# Altium schematic sheet MainSheet.SchDoc(MainSheet)
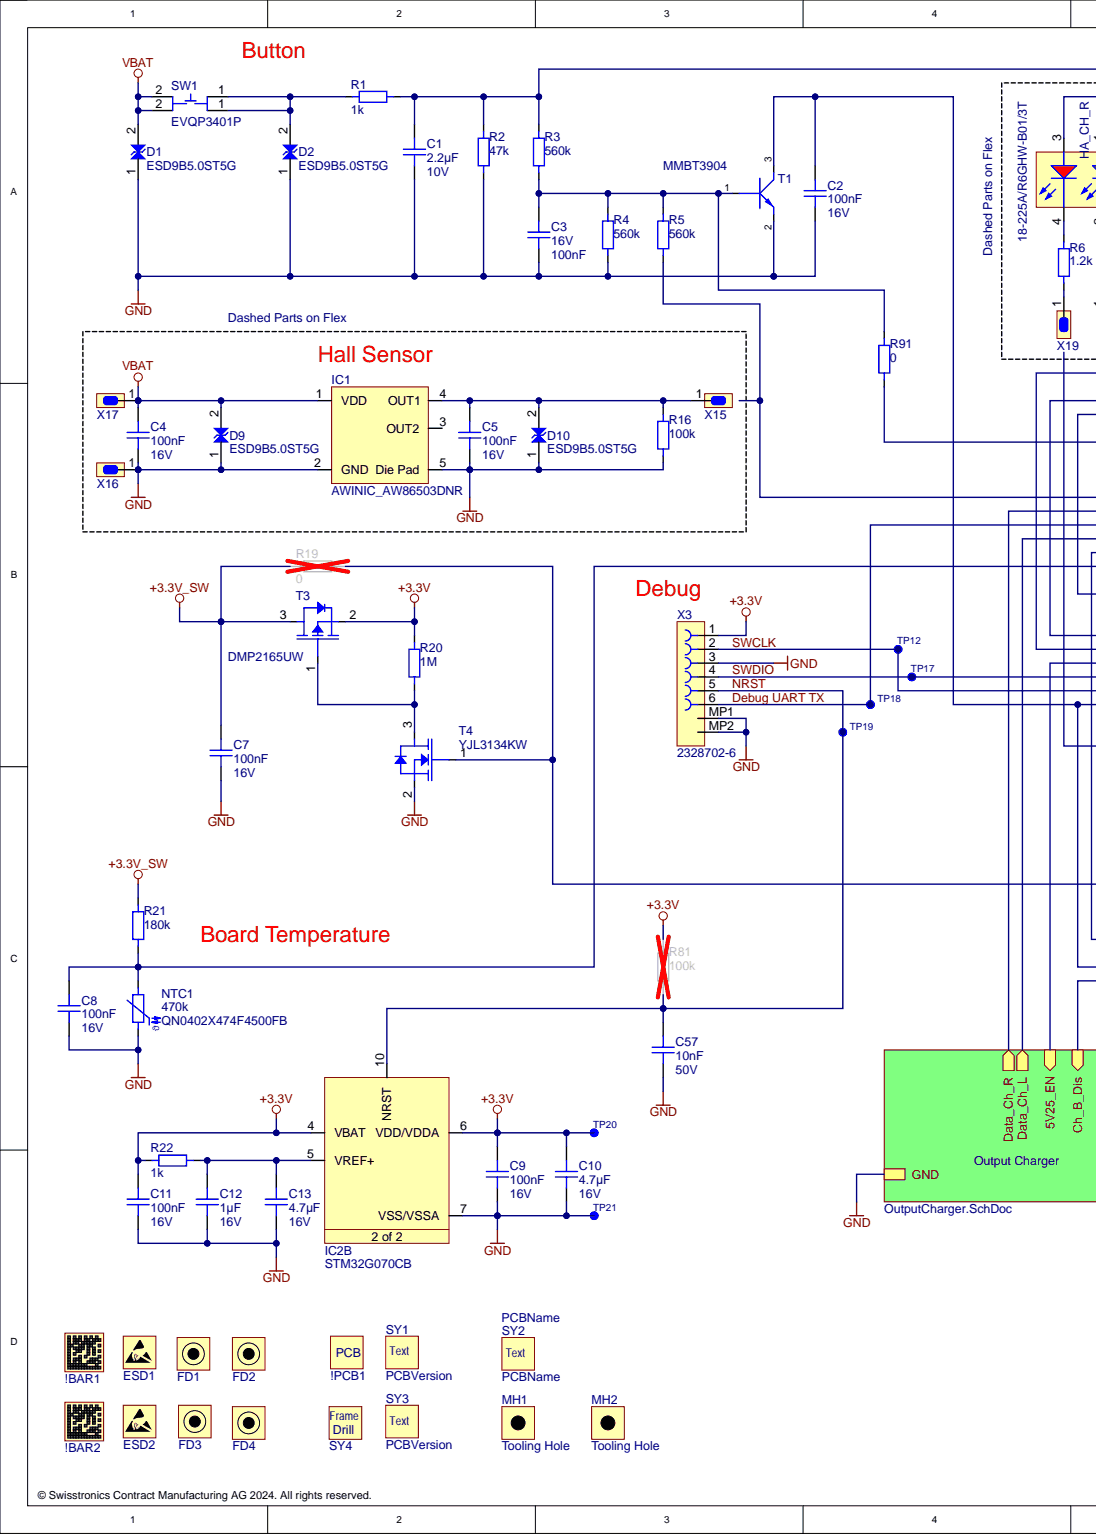
[diagram p0: MainSheet.SchDoc, left]
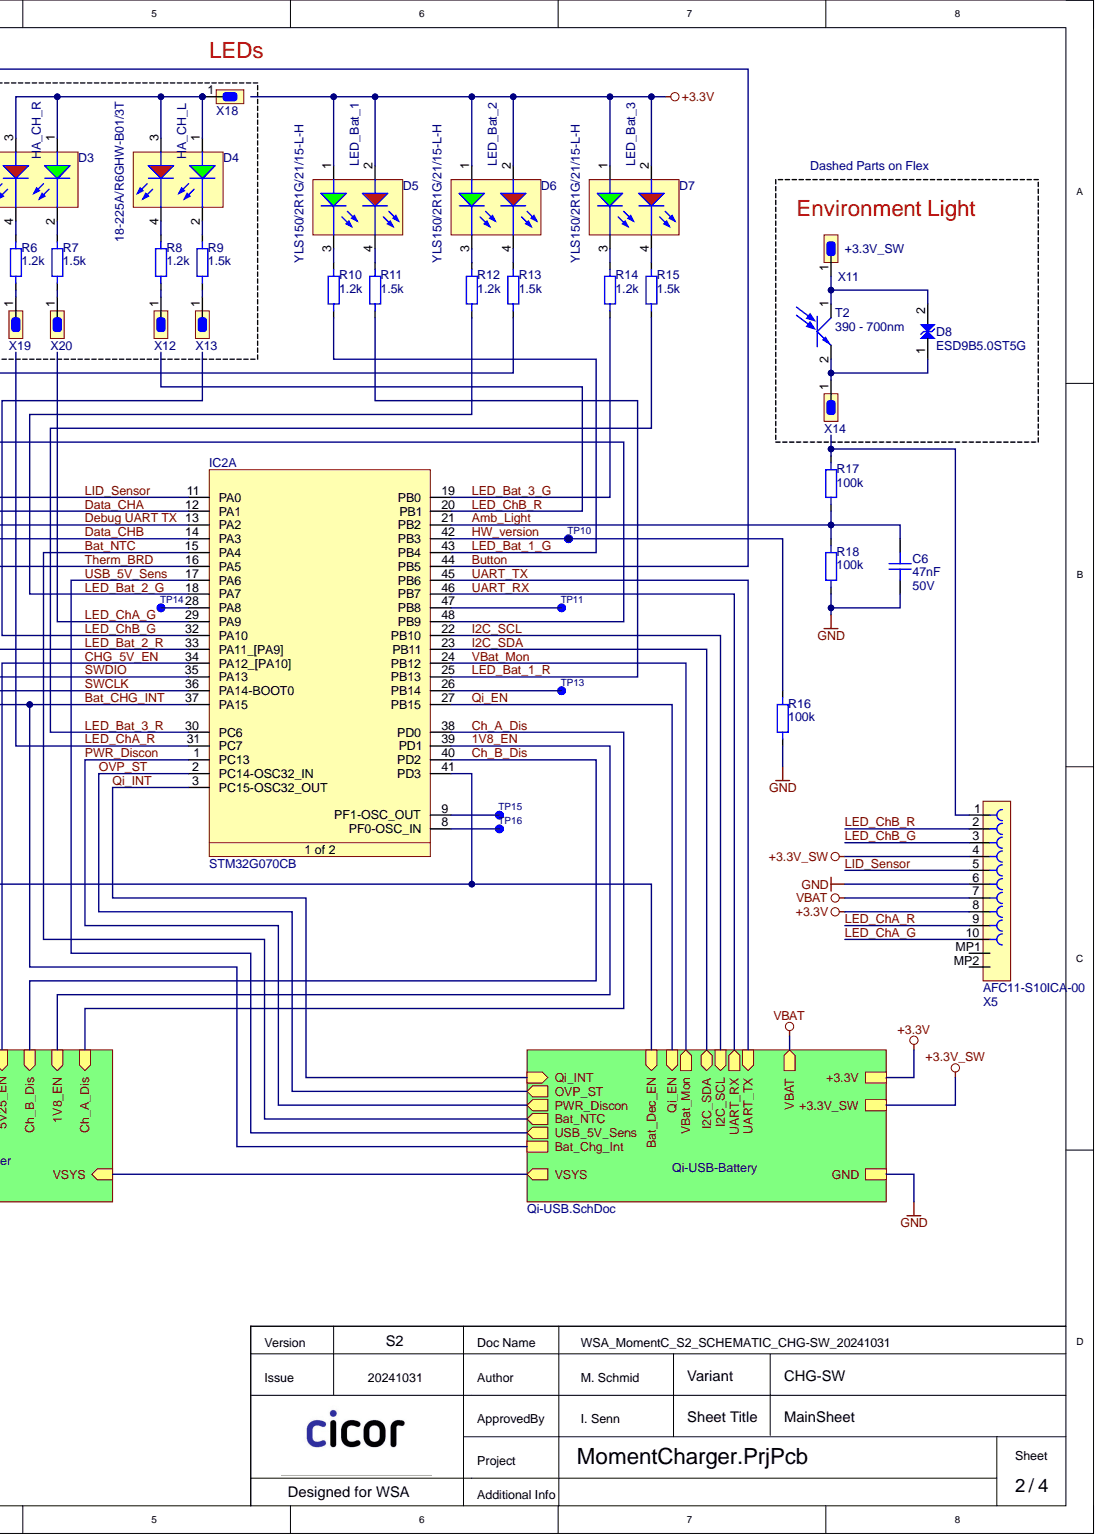
[diagram p1: MainSheet.SchDoc, right]

## Parts
!BAR1  LaserBarcode  [LASERWITHREVISION_4.2X4.2]  (value not drawn)  (no BOM)
!BAR2  LaserBarcode  [LASERWITHREVISION_4.2X4.2]  (value not drawn)  (no BOM)
!PCB1  PCB  (mechanical)
C1  value 2.2µF  VoltageRated: 10V  [CAPC1005X06N-0402IPC]  (hidden comment: C_2.2uF_10V_10%_X5R_0402)
C2  value 100nF  VoltageRated: 16V  [CAPC0603X02N-0201IPC]  (hidden comment: C_100nF_16V_10%_X5R_0201)
C3  value 100nF  VoltageRated: 16V  [CAPC0603X02N-0201IPC]  (hidden comment: C_100nF_16V_10%_X5R_0201)
C6  value 47nF  VoltageRated: 50V  [CAPC1005X06N-0402IPC]  (hidden comment: C_47nF_50V_10%_X7R_0402)
C7  value 100nF  VoltageRated: 16V  [CAPC0603X02N-0201IPC]  (hidden comment: C_100nF_16V_10%_X5R_0201)
C8  value 100nF  VoltageRated: 16V  [CAPC0603X02N-0201IPC]  (hidden comment: C_100nF_16V_10%_X5R_0201)
C9  value 100nF  VoltageRated: 16V  [CAPC0603X02N-0201IPC]  (hidden comment: C_100nF_16V_10%_X5R_0201)
C10  value 4.7µF  VoltageRated: 16V  [CAPC1005X06N-0402IPC]  (hidden comment: C_4.7uF_16V_20%_X5R_0402)
C11  value 100nF  VoltageRated: 16V  [CAPC0603X02N-0201IPC]  (hidden comment: C_100nF_16V_10%_X5R_0201)
C12  value 1µF  VoltageRated: 16V  [CAPC1005X06N-0402IPC]  (hidden comment: C_1uF_16V_20%_X5R_0402)
C13  value 4.7µF  VoltageRated: 16V  [CAPC1005X06N-0402IPC]  (hidden comment: C_4.7uF_16V_20%_X5R_0402)
C57  value 10nF  VoltageRated: 50V  [CAPC1005X06N-0402IPC]  (hidden comment: C_10nF_50V_10%_X5R_0402)
D1  value ESD9B5.0ST5G  Manufacturer3PN: ESD9B5.0ST5G  [SOD-923]  (hidden comment: Onsemi_ESD9B5.0)
D2  value ESD9B5.0ST5G  Manufacturer3PN: ESD9B5.0ST5G  [SOD-923]  (hidden comment: Onsemi_ESD9B5.0)
D3  value 18-225A/R6GHW-B01/3T  [18-225A/R6GHW-B01/3T]  (hidden comment: Everlight_18-225A/R6GHW-B01/3T)  (mechanical)
D4  value 18-225A/R6GHW-B01/3T  [18-225A/R6GHW-B01/3T]  (hidden comment: Everlight_18-225A/R6GHW-B01/3T)  (mechanical)
D5  value YLS150/2R1G/21/15-L-H  Manufacturer1PN: YLS150/2R1G/21/15-L-H  [Y.LIN YLS150/2R1G/21/15-L-H]  (hidden comment: YLIN_YLS150/2R1G/21/15-L-H)
D6  value YLS150/2R1G/21/15-L-H  Manufacturer1PN: YLS150/2R1G/21/15-L-H  [Y.LIN YLS150/2R1G/21/15-L-H]  (hidden comment: YLIN_YLS150/2R1G/21/15-L-H)
D7  value YLS150/2R1G/21/15-L-H  Manufacturer1PN: YLS150/2R1G/21/15-L-H  [Y.LIN YLS150/2R1G/21/15-L-H]  (hidden comment: YLIN_YLS150/2R1G/21/15-L-H)
D8  value ESD9B5.0ST5G  Manufacturer3PN: ESD9B5.0ST5G  [SOD-923]  (hidden comment: Onsemi_ESD9B5.0)  (mechanical)
ESD1  ESD-SYMBOL  [ESD-PCB_3mm]  (value not drawn)  (no BOM)
ESD2  ESD-SYMBOL  [ESD-PCB_3mm]  (value not drawn)  (no BOM)
FD1  FIDUCIAL_Pin0  [FIDUCIAL-10-18]  (value not drawn)  (no BOM)
FD2  FIDUCIAL_Pin0  [FIDUCIAL-10-18]  (value not drawn)  (no BOM)
FD3  FIDUCIAL_Pin0  [FIDUCIAL-10-18]  (value not drawn)  (no BOM)
FD4  FIDUCIAL_Pin0  [FIDUCIAL-10-18]  (value not drawn)  (no BOM)
IC2  value STM32G070CB  [STM-LQFP48_N]  (hidden comment: ST_STM32G070CBT6)  (parts A,B)
MH1  ToolingHole  value Tooling Hole  PartDescription: Tooling Hole  [ToolingHole_2.00]
MH2  ToolingHole  value Tooling Hole  PartDescription: Tooling Hole  [ToolingHole_2.00]
NTC1  value 470k  Manufacturer1PN: QN0402X474F4500FB  [RESC1005X04N-0402IPC]  (hidden comment: Longtech_QN0402X474F4500FB)
R1  value 1k  [RESC0603X02N-0201IPC]  (hidden comment: R_1k_50mW_200ppm_1%_0201)
R2  value 47k  [RESC0603X02N-0201IPC]  (hidden comment: R_47k_50mW_200ppm_1%_0201)
R3  value 560k  [RESC0603X02N-0201IPC]  (hidden comment: R_560k_50mW_200ppm_1%_0201)
R4  value 560k  [RESC0603X02N-0201IPC]  (hidden comment: R_560k_50mW_200ppm_1%_0201)
R5  value 560k  [RESC0603X02N-0201IPC]  (hidden comment: R_560k_50mW_200ppm_1%_0201)
R6  value 1.2k  [RESC0603X02N-0201IPC]  (hidden comment: R_1.2k_50mW_200ppm_1%_0201)  (mechanical)
R7  value 1.5k  [RESC0603X02N-0201IPC]  (hidden comment: R_1.5k_50mW_200ppm_1%_0201)  (mechanical)
R8  value 1.2k  [RESC0603X02N-0201IPC]  (hidden comment: R_1.2k_50mW_200ppm_1%_0201)  (mechanical)
R9  value 1.5k  [RESC0603X02N-0201IPC]  (hidden comment: R_1.5k_50mW_200ppm_1%_0201)  (mechanical)
R10  value 1.2k  [RESC0603X02N-0201IPC]  (hidden comment: R_1.2k_50mW_200ppm_1%_0201)
R11  value 1.5k  [RESC0603X02N-0201IPC]  (hidden comment: R_1.5k_50mW_200ppm_1%_0201)
R12  value 1.2k  [RESC0603X02N-0201IPC]  (hidden comment: R_1.2k_50mW_200ppm_1%_0201)
R13  value 1.5k  [RESC0603X02N-0201IPC]  (hidden comment: R_1.5k_50mW_200ppm_1%_0201)
R14  value 1.2k  [RESC0603X02N-0201IPC]  (hidden comment: R_1.2k_50mW_200ppm_1%_0201)
R15  value 1.5k  [RESC0603X02N-0201IPC]  (hidden comment: R_1.5k_50mW_200ppm_1%_0201)
R16  value 100k  [RESC0603X02N-0201IPC]  (hidden comment: R_100k_50mW_200ppm_1%_0201)
R17  value 100k  [RESC0603X02N-0201IPC]  (hidden comment: R_100k_50mW_200ppm_1%_0201)
R18  value 100k  [RESC0603X02N-0201IPC]  (hidden comment: R_100k_50mW_200ppm_1%_0201)
R19  value 0  [RESC0603X02N-0201IPC]  (hidden comment: R_0R_0.5A_0201)
R20  value 1M  [RESC0603X02N-0201IPC]  (hidden comment: R_1M_50mW_200ppm_1%_0201)
R21  value 180k  [RESC0603X02N-0201IPC]  (hidden comment: R_180k_50mW_200ppm_1%_0201)
R22  value 1k  [RESC0603X02N-0201IPC]  (hidden comment: R_1k_50mW_200ppm_1%_0201)
R81  value 100k  [RESC0603X02N-0201IPC]  (hidden comment: R_100k_50mW_200ppm_1%_0201)
R91  value 0  [RESC0603X02N-0201IPC]  (hidden comment: R_0R_0.5A_0201)
SW1  value EVQP3401P  Type: Button  [Push_4 - EVQP3]  (hidden comment: Panasonic_EVQP3401P)
SY1  PCBVersion  [PCBVersion_2.0]  (no BOM)
SY2  PCBName  [PCBName_1.0]  (no BOM)
SY3  PCBVersion  [PCBVersion_2.0]  (no BOM)
SY4  FrameDrill  [FrameA3_Hor_Drill_150%25_Draftsman]  (no BOM)
T1  value MMBT3904  [SOT-883]  (hidden comment: MCC_MMBT3904M)
T2  value 390 - 700nm  [DIOM1708X07N]  (hidden comment: Everlight_ALS-PT19-315C/L177/TR8)  (mechanical)
T3  value DMP2165UW  [SOT65P210X110-3N]  (hidden comment: Diodes_DMP2165UW-7)
T4  value YJL3134KW  [SOT-323-Yangjie]  (hidden comment: Yangjie_YJL3134KW)
TP10  TestPoint  [TP_080mm-Without-3D]  (value not drawn)  (no BOM)
TP11  TestPoint  [TP_080mm-Without-3D]  (value not drawn)  (no BOM)
TP12  TestPoint  [TP_080mm-Without-3D]  (value not drawn)  (no BOM)
TP13  TestPoint  [TP_080mm-Without-3D]  (value not drawn)  (no BOM)
TP14  TestPoint  [TP_080mm-Without-3D]  (value not drawn)  (no BOM)
TP15  TestPoint  [TP_080mm-Without-3D]  (value not drawn)  (no BOM)
TP16  TestPoint  [TP_080mm-Without-3D]  (value not drawn)  (no BOM)
TP17  TestPoint  [TP_080mm-Without-3D]  (value not drawn)  (no BOM)
TP18  TestPoint  [TP_080mm-Without-3D]  (value not drawn)  (no BOM)
TP19  TestPoint  [TP_080mm-Without-3D]  (value not drawn)  (no BOM)
TP20  TestPoint  [TP_080mm-Without-3D]  (value not drawn)  (no BOM)
TP21  TestPoint  [TP_080mm-Without-3D]  (value not drawn)  (no BOM)
X3  value 2328702-6  Manufacturer1PN: 2328702-6  [TE_2328702-6]  (hidden comment: TE_2328702-6)
X5  value AFC11-S10ICA-00  Manufacturer1PN: AFC11-S10ICA-00  [JUSHUO_AFC11-S10ICA-00]  (hidden comment: JUSHUO_AFC11-S10ICA-00)
X11  PCB_PLATED_EDGE_CON_1PAD  [PCB_PLATED_EDGE_CON_1PAD_15X03]  (value not drawn)  (mechanical)
X12  PCB_PLATED_EDGE_CON_1PAD  [PCB_PLATED_EDGE_CON_1PAD_15X03]  (value not drawn)  (mechanical)
X13  PCB_PLATED_EDGE_CON_1PAD  [PCB_PLATED_EDGE_CON_1PAD_15X03]  (value not drawn)  (mechanical)
X14  PCB_PLATED_EDGE_CON_1PAD  [PCB_PLATED_EDGE_CON_1PAD_15X03]  (value not drawn)  (mechanical)
X18  PCB_PLATED_EDGE_CON_1PAD  [PCB_PLATED_EDGE_CON_1PAD_15X03]  (value not drawn)  (mechanical)
X19  PCB_PLATED_EDGE_CON_1PAD  [PCB_PLATED_EDGE_CON_1PAD_15X03]  (value not drawn)  (mechanical)
X20  PCB_PLATED_EDGE_CON_1PAD  [PCB_PLATED_EDGE_CON_1PAD_15X03]  (value not drawn)  (mechanical)

## Nets
+3.3V: C9.1 C10.2 C11.1 D5.1 D5.2 D6.1 D6.2 D7.1 D7.2 IC2.4(VBAT) IC2.6(VDD/VDDA) R20.2 R22.1 R81.2 T3.2 TP20.1 X3.1 X5.8
+3.3V_SW: C7.1 R19.1 R21.2 T3.3 X5.4
GND: C1.1 C2.2 C3.2 C6.2 C7.2 C8.2 C9.2 C10.1 C11.2 C12.1 C13.1 C57.1 D1.1 D2.1 IC2.7(VSS/VSSA) NTC1.1 R2.1 R4.1 R16.1 R18.1 T1.2 T4.2 TP21.1 X3.3 X3.MP1 X3.MP2 X5.6
VBAT: D1.2 SW1.2 X5.7
1V8_EN: IC2.39(PD1)
Amb_Light: C6.1 IC2.21(PB2) R17.1 R18.2
Bat_CHG_INT: C2.1 IC2.37(PA15) T1.3
Bat_NTC: IC2.15(PA4)
Button: C1.2 IC2.44(PB5) R1.2 R2.2 R3.2
Ch_A_Dis: IC2.38(PD0)
Ch_B_Dis: IC2.40(PD2)
CHG_5V_EN: IC2.34(PA12_[PA10])
Data_CHA: IC2.12(PA1)
Data_CHB: IC2.14(PA3)
Debug UART TX: IC2.13(PA2) TP18.1 X3.6
HW_version: IC2.42(PB3) R16.2 TP10.1
I2C_SCL: IC2.22(PB10)
I2C_SDA: IC2.23(PB11)
LED_Bat_1_G: IC2.43(PB4) R10.1
LED_Bat_1_R: IC2.25(PB13) R11.1
LED_Bat_2_G: IC2.18(PA7) R12.1
LED_Bat_2_R: IC2.33(PA11_[PA9]) R13.1
LED_Bat_3_G: IC2.19(PB0) R14.1
LED_Bat_3_R: IC2.30(PC6) R15.1
LED_ChA_G: IC2.29(PA9) X5.10
LED_ChA_R: IC2.31(PC7) X5.9
LED_ChB_G: IC2.32(PA10) X5.3
LED_ChB_R: IC2.20(PB1) X5.2
LID_Sensor: IC2.11(PA0) R5.1 X5.5
NRST: C57.2 IC2.10(NRST) R81.1 TP19.1 X3.5
OVP_ST: IC2.2(PC14-OSC32_IN)
PWR_Discon: IC2.1(PC13)
Qi_EN: IC2.27(PB15)
Qi_INT: IC2.3(PC15-OSC32_OUT)
SWCLK: IC2.36(PA14-BOOT0) TP12.1 X3.2
SWDIO: IC2.35(PA13) TP17.1 X3.4
Therm_BRD: C8.1 IC2.16(PA5) NTC1.2 R21.1
UART_RX: IC2.46(PB7)
UART_TX: IC2.45(PB6)
USB_5V_Sens: IC2.17(PA6)
VBat_Mon: IC2.24(PB12)
NetC3_1: C3.1 R3.1 R4.2 R5.2 R91.2 T1.1
NetC12_2: C12.2 C13.2 IC2.5(VREF+) R22.2
NetD2_2: D2.2 R1.1 SW1.1
NetD3_1: D3.1 D3.3 D4.1 D4.3 X18.1
NetD3_2: D3.2 R7.2
NetD3_4: D3.4 R6.2
NetD4_2: D4.2 R9.2
NetD4_4: D4.4 R8.2
NetD5_3: D5.3 R10.2
NetD5_4: D5.4 R11.2
NetD6_3: D6.3 R12.2
NetD6_4: D6.4 R13.2
NetD7_3: D7.3 R14.2
NetD7_4: D7.4 R15.2
NetD8_1: D8.1 T2.2 X14.1
NetD8_2: D8.2 T2.1 X11.1
NetFD1_0: FD1.0
NetFD2_0: FD2.0
NetFD3_0: FD3.0
NetFD4_0: FD4.0
NetIC2_8: IC2.8(PF0-OSC_IN) TP16.1
NetIC2_9: IC2.9(PF1-OSC_OUT) TP15.1
NetIC2_26: IC2.26(PB14) TP13.1
NetIC2_28: IC2.28(PA8) TP14.1
NetIC2_41: IC2.41(PD3) R19.2 T4.1
NetIC2_47: IC2.47(PB8) TP11.1
NetIC2_48: IC2.48(PB9) R91.1
NetR6_1: R6.1 X19.1
NetR7_1: R7.1 X20.1
NetR8_1: R8.1 X12.1
NetR9_1: R9.1 X13.1
NetR17_2: R17.2 X5.1
NetR20_1: R20.1 T3.1 T4.3
NetX5_MP1: X5.MP1
NetX5_MP2: X5.MP2
VSYS:

## Library data of the parts
!BAR1 !BAR2 = CMP-00078-12: Manufacturer1: various; Manufacturer1PN: various; Modified: 03.11.2022; PartNumber: LaserBarcode; PartNumberCicor: LaserBarcode; PartNumberR&D: LaserBarcode; Status: new; Library Name: SWT
!PCB1 = CMP-415-000009-12: V2; Manufacturer1: various; PartDescription: WSA MomentC V2; Manufacturer1PN: various; Modified: 03.08.2017; PartNumber: PCB; PartNumberCicor: PCB; PartNumberR&D: PCB; Status: used; Library Name: SWT
C1 = CMP-263-000125-8: Cap.Cer,2.2uF,10%,10V,X5R,0402; Manufacturer1: MURATA; Manufacturer1PN: GRM155R61A225KE95D; Manufacturer2PN: CL05A225KP5NSNC; PartNumber: 4056945; Type: Ceramic; Component Kind: Standard; Dielectric: X5R; Housing: 0402; Manufacturer2: SAMSUNG; Manufacturer3: various; Manufacturer3PN: C_2.2uF_10V_10%_X5R_0402; PartNumberCicor: 4056945; Tolerance: 10%; Library Name: SWT
C2 C3 C7-C9 C11 = CMP-263-000020-10: CAP.CER 100nF 16V X5R 0201; Manufacturer1: Yageo; Manufacturer1PN: CC0201KRX5R7BB104; PartNumber: 4079999; PartNumberCicor: 100nF/16V/X5R/0603(0201)/10%; TempRange: -40°C/+85°C; Tolerance: 10%; Type: Ceramic; Component Kind: Standard; Dielectric: X5R; Housing: 0201; Manufacturer2: Various; Manufacturer2PN: C_100nF_16V_10%_X5R_0201; Library Name: SWT
C6 = CMP-263-000010-10: CAP.CER 47nF 50V 10% X7R 0402; Manufacturer1: TDK; Manufacturer1PN: CGA2B3X7R1H473K050BB; PartNumber: 4078189; TempRange: -55°C/+125°C; Type: Ceramic; Component Kind: Standard; Dielectric: X7R; Housing: 0402; Manufacturer2: various; Manufacturer2PN: C_47nF_50V_10%_X7R_0402; PartDescription: CAP.CER 47nF 50V 10% X7R 0402; PartNumberCicor: 4078189; Tolerance: 10%; Library Name: SWT
C10 C13 = CMP-00454-1: Cap.Cer,4.7uF,20%,16V,X5R,0402; Manufacturer1: KYOCERA AVX; Manufacturer1PN: KGM05CR51C475MH; Type: Ceramic; Component Kind: Standard; Dielectric: X5R; Housing: 0402; Manufacturer2: various; Manufacturer2PN: C_4.7uF_16V_20%_X5R_0402; Tolerance: 20%; Library Name: SWT
C12 = CMP-263-000205-9: Cap.Cer 1uF 20% 16V X5R 0402; Manufacturer1: Murata; Manufacturer1PN: GRM155R61C105MA12D; TempRange: -55°C/+85°C; Type: Ceramic; Component Kind: Standard; Dielectric: X5R; Housing: 0402; Manufacturer2: various; Manufacturer2PN: C_1uF_16V_20%_X5R_0402; PartNumberCicor: C_1uF_16V_20%_X5R_0402; Tolerance: 20%; Library Name: SWT
C57 = CMP-00456-1: 10 nF; Manufacturer1: Cal-Chip Electronics, Inc.; Manufacturer1PN: GMC04X5R103K50NT; PartDescription: CAP.CERAMIC 10N/50V 10% 0402; Dielectric: X5R; Tolerance: 10%; Type: Ceramic; Component Kind: Standard; Housing: 0402; Manufacturer2: various; Manufacturer2PN: C_10nF_50V_10%_X5R_0402; Library Name: SWT
D1 D2 D8 = CMP-00108-13: TVS DIODE 5VWM SOD923; Manufacturer1: LRC; Manufacturer1PN: LESD9D5.0CT5G; Manufacturer2PN: ESD9D5C; PartNumber: onsemi_ESD9B5.0ST5G; TempRange: -55°C/+150°C; VoltageBreakdown: 5.8V; VoltageRated: 5V; Availability: SiliconExpert 02.02.2023: 6.6 years; ComponentLink1Description: Datasheet; ComponentLink1URL: \\CTCHFS01.cicor.local\epg$\EDA\Documents\Datasheets\ESD9B-D.PDF; ComponentLink2Description: Datasheet Web; ComponentLink2URL: https://www.onsemi.cn/pdf/datasheet/esd9b-d.pdf; ComponentLink3Description: Datasheet Leiditech; ComponentLink3URL: \\CTCHFS01.cicor.local\epg$\EDA\Documents\Datasheets\ESD9D5C.pdf; ComponentLink4Description: Datasheet Leiditech Web; ComponentLink4URL: https://de.leiditech.com/u_file/file/products/2212/16/86a2a579d2.pdf; ComponentLink5Description: Datasheet LRC; ComponentLink5URL: \\CTCHFS01.cicor.local\epg$\EDA\Documents\Datasheets\LRC_LESD9D5.0CT5G.pdf; Housing: SOD923; Manufacturer2: Leiditech; Manufacturer3: Onsemi; PartNumberCicor: onsemi_ESD9B5.0ST5G; Library Name: SWT
  D1 D2: Component Kind: Standard
D3 D4 = CMP-004-00011-5: 18-225A/R6GHW-B01/3T; PartDescription: 2 LED green and red; Color: Green/Red; Availability: SiliconExpert 02.02.2023: 5.6 years; ComponentLink1Description: Datasheet; ComponentLink1URL: \\CTCHFS01.cicor.local\epg$\EDA\Documents\Datasheets\18-225a-r6ghw-b01-3t.pdf; Manufacturer1: Everlight; Manufacturer1PN: 18-225A/R6GHW-B01/3T; Library Name: SWT
D5-D7 = CMP-00481-5: LED DUO red / green; Manufacturer1: Y.LIN; Brightness: Red: 160-350 mcd / Green: 600-1300 mcd; CurrentRating: 25mA; ForwardVoltage: R: 1.8-2.4V, G: 2.8-3.4V; PowerDissipation: R: 60mW, G: 85mW; Color: red/green; Component Kind: Standard; ComponentLink1Description: Datasheet; ComponentLink1URL: \\CTCHFS01.cicor.local\epg$\EDA\Documents\Datasheets\Y.LIN_YLS 1502R1G2115.pdf; Library Name: SWT
ESD1 ESD2 = CMP-415-000003-7: Comment; PartDescription: ESD-Symbol; PartNumber: ESD-SYMBOL; PartNumberCicor: ESD-SYMBOL; PartNumberR&D: ESD-SYMBOL; Status: new; Library Name: SWT
FD1-FD4 = CMP-414-000002-4: Comment; PartDescription: FICUCIAL Round; PartNumber: FIDUCIAL_Pin0; PartNumberCicor: FIDUCIAL_Pin0; PartNumberR&D: FIDUCIAL_Pin0; Status: used; Library Name: SWT; Modified: 26.02.2021 JAA; 03.02.2023 no BOM SM
IC2 = CMP-00455-8: RISC Microcontroller, 32-Bit, 64MHz; Manufacturer1: ST; Core: Arm® 32-bit Cortex®-M0+ CPU; VoltageRated: 3.3V (2-3.6V); Component Kind: Standard; ComponentLink1Description: Reference Manual; ComponentLink1URL: \\CTCHFS01.cicor.local\epg$\EDA\Documents\Datasheets\rm0454-stm32g0x0-advanced-armbased-32bit-mcus-stmicroelectronics.pdf; ComponentLink2Description: Reference Manual Web; ComponentLink2URL: https://www.st.com/resource/en/reference_manual/rm0454-stm32g0x0-advanced-armbased-32bit-mcus-stmicroelectronics.pdf; ComponentLink3Description: Datasheet; ComponentLink3URL: \\CTCHFS01.cicor.local\epg$\EDA\Documents\Datasheets\stm32g070cb.pdf; ComponentLink4Description: Datasheet Web; ComponentLink4URL: https://www.st.com/resource/en/datasheet/stm32g070cb.pdf; Housing: LQFP48; Manufacturer1PN: STM32G070CBT6; Library Name: SWT
MH1 MH2 = CMP-414-000001-11: Comment; Component Kind: Standard; PartNumber: ToolingHole; PartNumberCicor: ToolingHole; PartNumberR&D: ToolingHole; Status: used; Library Name: SWT
NTC1 = CMP-00478-2: Chip Temperature Sensing NTC Thermistor; Manufacturer1: QAM; TempRange: -40°C/+125°C; Tolerance: 1 %; Availability: SiliconExpert ?; Component Kind: Standard; ComponentLink1Description: Datasheet; ComponentLink1URL: \\CTCHFS01.cicor.local\epg$\EDA\Documents\Datasheets\QN0402X474F3950FB  QAM NTC.pdf; Housing: 0402; PartDescription: NTC; Type: NTC; Library Name: SWT
R1 R22 = CMP-232-000484-6: RES 1k 50mW 200ppm 1% 0201 k; Manufacturer1: Yageo; Manufacturer1PN: RC0201FR-071KL; Component Kind: Standard; Housing: 0201; Manufacturer2: various; Manufacturer2PN: R_1k_50mW_200ppm_1%_0201; PartNumber: R_1k_50mW_200ppm_1%_0201; PartNumberCicor: R_1k_50mW_200ppm_1%_0201; PowerDissipation: 50mW; PPM: 200 ppm; Tolerance: 1%; Library Name: SWT
R2 = CMP-232-000490-4: RES 47k 50mW 200ppm 1% 0201; Manufacturer1: Vishay; Manufacturer1PN: CRCW020147K0FNED; Component Kind: Standard; Housing: 0201; Manufacturer2: various; Manufacturer2PN: R_47k_50mW_200ppm_1%_0201; PartNumberCicor: R_47k_50mW_200ppm_1%_0201; PowerDissipation: 50mW; PPM: 200 ppm; Tolerance: 1%; Library Name: SWT
R3-R5 = CMP-00459-3: RES 560k 50mW 200ppm 1% 0201; Manufacturer1: Kamaya Inc.; Manufacturer1PN: RMC1/20-5603FPA; Manufacturer2PN: RMCF0201FT560K; Component Kind: Standard; Housing: 0201; Manufacturer2: Stackpole Electronics Inc.; Manufacturer3: various; Manufacturer3PN: R_560k_50mW_200ppm_1%_0201; PowerDissipation: 50mW; PPM: 200 ppm; Tolerance: 1%; Library Name: SWT
R6 R8 R10 R12 R14 = CMP-00471-3: RES 1.2k 50mW 200ppm 1% 0201; Manufacturer1: Yageo; Manufacturer1PN: RC0201FR-071K2L; Manufacturer3PN: RMCF0201JT1K20; Housing: 0201; Manufacturer2: Various; Manufacturer2PN: R_1.2k_50mW_200ppm_1%_0201; PowerDissipation: 50mW; PPM: 200 ppm; Tolerance: 1%; Library Name: SWT
  R10 R12 R14: Component Kind: Standard
R7 R9 R11 R13 R15 = CMP-232-000482-5: RES 1.5k 50mW 200ppm 1% 0201; Manufacturer1: Delta Electronics/Cyntec; Manufacturer1PN: PFR03S-152-FNH; Housing: 0201; Manufacturer2: Various; Manufacturer2PN: R_1.5k_50mW_200ppm_1%_0201; PowerDissipation: 50mW; PPM: 200 ppm; Tolerance: 1%; Library Name: SWT
  R11 R13 R15: Component Kind: Standard
R16-R18 R81 = CMP-232-000486-8: RES 100k 50mW 200ppm 1% 0201; Manufacturer1: Bourns; Manufacturer1PN: CR0201-FW-1003GLF; Component Kind: Standard; Housing: 0201; Manufacturer2: various; Manufacturer2PN: R_100k_50mW_200ppm_1%_0201; PartNumberCicor: R_100k_50mW_200ppm_1%_0201; PartNumberR&D: R_100k_50mW_200ppm_1%_0201; PowerDissipation: 50mW; PPM: 200 ppm; Tolerance: 1%; Library Name: SWT
R19 R91 = CMP-232-000030-6: RES 0R 0201; Manufacturer1: PANASONIC (MATSUSHITA); Manufacturer1PN: ERJ-1GN0R00C; PartNumber: 4080046; Tolerance: 0%; Component Kind: Standard; Manufacturer2: various; Manufacturer2PN: R_0R_0.5A_0201; PartNumberCicor: 4080046; Library Name: SWT
R20 = CMP-232-000473-6: RES 1M 50mW 200ppm 1% 0201; Manufacturer1: KOA Speer; Manufacturer1PN: RK73H1HTTC1004F; Component Kind: Standard; Housing: 0201; Manufacturer2: various; Manufacturer2PN: R_1M_50mW_200ppm_1%_0201; PowerDissipation: 50mW; PPM: 200 ppm; Tolerance: 1%; Library Name: SWT
R21 = CMP-00467-2: RES 180k 50mW 200ppm 1% 0201; Manufacturer1: YAGEO; Manufacturer1PN: RC0201FR-07180KL; Component Kind: Standard; Housing: 0201; Manufacturer2: Various; Manufacturer2PN: R_180k_50mW_200ppm_1%_0201; PowerDissipation: 50mW; PPM: 200 ppm; Tolerance: 1%; Library Name: SWT
SW1 = CMP-00113-3: Tactile Push button 90°; Manufacturer1PN: EVQ-P3401P; PartDescription: SWI.Push Microtaster 90°; CurrentRating: 50mA; VoltageRated: 12V; Availability: SiliconExpert 02.02.2023: 7.5 Years, SiliconExpert 29.07.2024: 10.4 Years; Component Kind: Standard; ComponentLink1Description: Datasheet; ComponentLink1URL: \\CTCHFS01.cicor.local\epg$\EDA\Documents\Datasheets\2431_fileversion.pdf; ComponentLink2Description: DatasheetWeb; ComponentLink2URL: https://www.mouser.ch/datasheet/2/315/sw_lt_eng_3529s_side-1289027.pdf; Manufacturer1: Panasonic; PartNumber: Panasonic_EVQP3401P; PartNumberCicor: Panasonic_EVQP3401P; Library Name: SWT; Modified: 19.01.2023; 02.02.2023 FS
SY1 SY3 = CMP-415-000017-6: PCB.Vers; PartDescription: Text im Kupfer mit Variable PCBVersion; PartNumber: PCBVersion; PartNumberCicor: PCBVersion; PartNumberR&D: PCBVersion; Status: used; Library Name: SWT; Modified: 19.10.2023 MUL
SY2 = CMP-415-000015-5: PCB.Name; PartDescription: Text im Kupfer mit Variable PCBName; Status: used; Value: PCBName; Library Name: SWT; Modified: 19.10.2023 MUL
SY4 = CMP-415-000006-14: WSA_MomentC_V2_PCB-Spezifications_; DocNameText: WSA_MomentC_V2_PCB-Spezifications_; PartNumber: FrameDrill; PartNumberCicor: FrameDrill; PartNumberR&D: FrameDrill; Status: new; Library Name: SWT; Modified: 09.08.2017, 18.10.2023 MUL
T1 = CMP-00098-9: NPN Transistor 40 V 0.2A, SOT883; Manufacturer1: MCC; Manufacturer1PN: MMBT3904L3; Manufacturer2PN: MMBT3904M-TP; CurrentRating: 200mA; TempRange: -55°C/+150°C; VoltageRated: 40V; Availability: SiliconExpert 20.05.2020: 6.4 Years; Component Kind: Standard; ComponentLink1Description: Datasheet; ComponentLink1URL: \\CTCHFS01.cicor.local\epg$\EDA\Documents\Datasheets\MMBT3904M(SOT-883)-V1.pdf; ComponentLink2Description: Datasheet MMBT3904L3; ComponentLink2URL: \\CTCHFS01.cicor.local\epg$\EDA\Documents\Datasheets\MCC_MMBT3904L3.pdf; Housing: SOT-883; Manufacturer2: MCC; PartDescription: NPN Transistor 40 V 0.2A, SOT883; Type: NPN; Library Name: SWT
T2 = CMP-00468-3: Ambient Light Sensor SMD; Type: Phototransistor; Value: 390 - 700nm; VoltageRated: 2.5 - 5.5V; ComponentLink1Description: Datasheet Web; ComponentLink1URL: https://www.lcsc.com/datasheet/lcsc_datasheet_1806131537_Everlight-Elec-ALS-PT19-315C-L177-TR8_C146233.pdf; Manufacturer1: Everlight; Manufacturer1PN: ALS-PT19-315C/L177/TR8; Library Name: SWT
T3 = CMP-00111-6: PMF170XP,115; Manufacturer1: Diodes; Manufacturer2PN: PJC7407_R1_00001; Manufacturer4PN: YJL2101W; PartDescription: TRN.MOSFET P FDN304P; CurrentRating: -1A; RDS_ON: 0.2; RDS_ON_@: 200mR@-4.5V/1A; TempRange: -55°C/+150°C; Type: P; VoltageRated: -20V; Availability: SiliconExpert 19.01.2023: 6.4 years - 8 years; Component Kind: Standard; ComponentLink1Description: Datasheet Nexperia; ComponentLink1URL: \\CTCHFS01.cicor.local\epg$\EDA\Documents\Datasheets\PMF170XP.pdf; ComponentLink2Description: Datasheet Nexperia Web; ComponentLink2URL: https://assets.nexperia.com/documents/data-sheet/PMF170XP.pdf; ComponentLink3Description: Datasheet Panjit; ComponentLink3URL: \\CTCHFS01.cicor.local\epg$\EDA\Documents\Datasheets\PJC7407.pdf; ComponentLink4Description: Datasheet Panjit Web; ComponentLink4URL: https://www.panjit.com.tw/upload/datasheet/PJC7407.pdf; ComponentLink5Description: Datasheet Diodes; ComponentLink5URL: \\CTCHFS01.cicor.local\epg$\EDA\Documents\Datasheets\DMP2165UW.pdf; ComponentLink6Description: Datasheet Diodes Web; ComponentLink6URL: https://www.diodes.com/assets/Datasheets/DMP2165UW.pdf; ComponentLink7Description: Datasheet Yangjie; ComponentLink7URL: \\CTCHFS01.cicor.local\epg$\EDA\Documents\Datasheets\Yangjie YJL2101W.pdf; ComponentLink8Description: Datasheet Yangjie Web; ComponentLink8URL: https://www.21yangjie.com/ggs/mosfet/zdymosfet/YJL2101W.pdf; Housing: SOT65P210X110; Manufacturer1PN: DMP2165UW-7; Manufacturer2: Panjit International Inc.; Manufacturer3: Nexperia USA Inc.; Manufacturer3PN: PMF170XP,115; Manufacturer4: Yangzhou Yangjie Electronic Technology Co., Ltd.; Library Name: SWT; Modified: 19.01.2023 SM; 02.02.2023 FS; add source 10.02.2023 SM
T4 = CMP-00119-5: YJL3134KW; Manufacturer1: Yangzhou Yangjie Electronic Technology Co.,Ltd; Manufacturer2PN: PJC7406_R1_00001; Manufacturer3PN: SI3134KWA-TP; Manufacturer4PN: YJL3134KBW; PartDescription: TRN.MOSFET N YJL3134KW 20V 0.50A; CurrentRating: 0.50 A; RDS_ON: 300mR@4.5V/0.5A; TempRange: -55°C/+150°C; Type: N; VoltageRated: 20 V; Availability: SiliconExpert 20.01.2023: 7.5 years; Component Kind: Standard; ComponentLink1Description: Datasheet Yangjie; ComponentLink1URL: \\CTCHFS01.cicor.local\epg$\EDA\Documents\Datasheets\YJL3134KW.pdf; ComponentLink2Description: Datasheet Yangjie Web; ComponentLink2URL: https://www.21yangjie.com/style/pdf/low-voltage-mosfet/YJL3134KW.pdf; ComponentLink3Description: Datasheet Panjit; ComponentLink3URL: \\CTCHFS01.cicor.local\epg$\EDA\Documents\Datasheets\PJC7406.pdf; ComponentLink4Description: Datasheet Panjit Web; ComponentLink4URL: https://www.panjit.com.tw/upload/datasheet/PJC7406.pdf; ComponentLink5Description: Datasheet Micro; ComponentLink5URL: \\CTCHFS01.cicor.local\epg$\EDA\Documents\Datasheets\SI3134KWA(SOT-323).pdf; ComponentLink6Description: Datasheet Micro Web; ComponentLink6URL: https://www.mccsemi.com/pdf/Products/SI3134KWA(SOT-323).pdf; ComponentLink7Description: Datasheet Yangjie; ComponentLink7URL: \\CTCHFS01.cicor.local\epg$\EDA\Documents\Datasheets\SI3134KWA(SOT-323).pdf; ComponentLink8Description: Datasheet Yangjie Web; ComponentLink8URL: https://www.21yangjie.com/ggs/mosfet/zdymosfet/YJL3134KBW.pdf; Manufacturer1PN: YJL3134KW; Manufacturer2: Panjit International Inc.; Manufacturer3: Micro Commercial Co; Manufacturer4: Yangzhou Yangjie Electronic Technology Co., Ltd.; PartNumberR&D: Yangjie_YJL3134KW; Status: new&checked; Library Name: SWT; Modified: 20.01.2023 SM; 08.02.2023 KABE; add source 10.02.2023 SM
TP10-TP21 = CMP-370-000002-6: TP; PartNumber: TP_mini; Manufacturer1: -; Manufacturer1PN: -; PartNumberCicor: TP_mini; PartNumberR&D: TP_mini; Value: TP; Library Name: SWT
X3 = CMP-00479-3: CONN FPC BOTH 6POS 0.50MM R/A; Manufacturer1: TE Connectivity; Contacts: 6; Current: 500mA; TempRange: -40°C/+85°C; VoltageRated: 50V; Availability: SiliconExpert 07.08.2024: 10.8 Years; Component Kind: Standard; ComponentLink1Description: Datasheet; ComponentLink1URL: \\CTCHFS01.cicor.local\epg$\EDA\Documents\Datasheets\ENG_CD_2328702_6-2033526.pdf; ComponentLink2Description: DatasheetWeb; ComponentLink2URL: https://www.mouser.ch/datasheet/2/418/8/ENG_CD_2328702_6-2033526.pdf; Supplier1: Mouser; Supplier1PN: 571-2328702-6; Library Name: SWT
X5 = CMP-00550-1: CON.DIV FFC/FPC  P0.5 10POL; Manufacturer1: JUSHUO; Manufacturer2PN: FCZ-010-V050-99/RP; Contacts: 10; Availability: SiliconExpert 25.10.2024: Unknown; Component Kind: Standard; ComponentLink1Description: Datasheet; ComponentLink1URL: \\CTCHFS01.cicor.local\epg$\EDA\Documents\Datasheets\AFC11-S10ICA-00.pdf; ComponentLink2Description: Datasheet Web; ComponentLink2URL: https://file.elecfans.com/web1/M00/86/24/o4YBAFx8f96AJTv1AAKKXxY44cQ813.pdf; ComponentLink3Description: Datasheet E-tec; ComponentLink3URL: \\CTCHFS01.cicor.local\epg$\EDA\Documents\Datasheets\91-reel.pdf; ComponentLink4Description: Datasheet E-tec Web; ComponentLink4URL: https://www.e-tec.com/v5/documents/pdfs/pcb-interconnect-products/91-reel.pdf; Manufacturer2: E-tec; Library Name: SWT
X11-X14 X18-X20 = CMP-00189-12: PCB PLATED EDGE CONNECTOR 1PAD for Stamp (Briefmarke) or Flex Connector; Manufacturer1: various; Contacts: 1; Value: 1pin, pitch variabel; Manufacturer1PN: PCB_PLATED_EDGE_CON_1PAD; Library Name: SWT
